# Altium schematic sheet PiggySat-radio.SchDoc(PiggySat-radio)
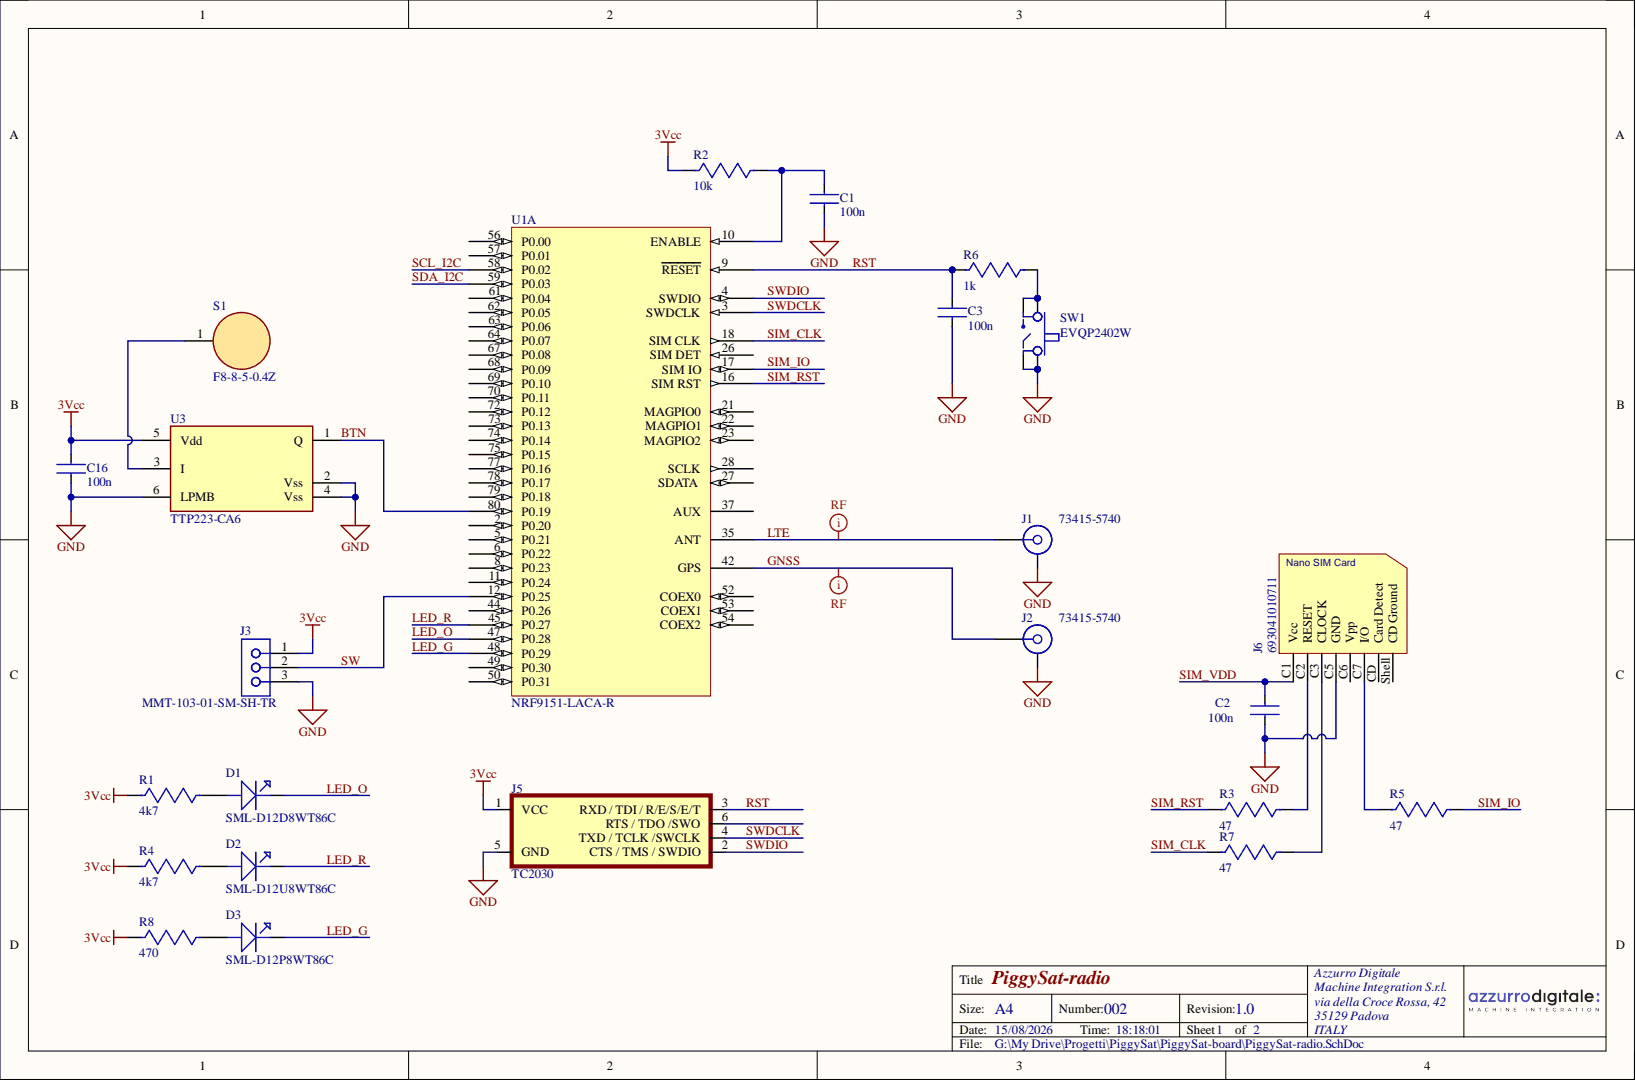
[diagram p0: PiggySat-radio.SchDoc]

## Parts
C1  100n  [CAPC1608X06L]
C2  100n  [CAPC1608X06L]
C3  100n  [CAPC1608X06L]
C16  100n  [CAPC1608X06L]
D1  SML-D12D8WT86C  [SML-D12]
D2  SML-D12U8WT86C  [SML-D12]
D3  SML-D12P8WT86C  [SML-D12]
J1  73415-5740  [MOLEX_734155740]
J2  73415-5740  [MOLEX_734155740]
J3  MMT-103-01-SM-SH-TR  [MMT-103-01-SM-SH-TR]
J5  TC2030  [TC2030-IDC]  (no BOM)
J6  693041010711  [693041010711]
R1  4k7  [RESC1005X04L]
R2  10k  [RESC1005X04L]
R3  47  [RESC1005X04L]
R4  4k7  [RESC1005X04L]
R5  47  [RESC1005X04L]
R6  1k  [RESC1005X04L]
R7  47  [RESC1005X04L]
R8  470  [RESC1005X04L]
S1  F8-8-5-0.4Z  [F8-8-5-0.4Z]
SW1  EVQP2402W  [EVQP2402W]
U1  NRF9151-LACA-R  [FP-nRF9151-LACA-R_MFG]  (part A)
U3  TTP223-CA6  [SOT-23-6L]

## Nets
3Vcc: C16.1 J3.1 J5.1(VCC) R1.1 R2.1 R4.1 R8.1 U3.5(Vdd)
GND: C1.2 C2.1 C3.1 C16.2 J1.2 J2.2 J3.3 J5.5(GND) J6.C5(GND) SW1.1 SW1.3 U3.2(Vss) U3.4(Vss) U3.6(LPMB)
BTN: U1.80(P0.19) U3.1(Q)
GNSS: J2.1 U1.42(GPS)
LED_G: D3.2 U1.48(P0.29)
LED_O: D1.2 U1.47(P0.28)
LED_R: D2.2 U1.45(P0.27)
LTE: J1.1 U1.35(ANT)
RST: C3.2 J5.3 R6.2 U1.9(RESET)
SCL_I2C: U1.58(P0.02)
SDA_I2C: U1.59(P0.03)
SIM_CLK: R7.1 U1.18(SIM_CLK)
SIM_IO: R5.2 U1.17(SIM_IO)
SIM_RST: R3.1 U1.16(SIM_RST)
SIM_VDD: C2.2 J6.C1(Vcc)
SW: J3.2 U1.12(P0.25)
SWDCLK: J5.4(TXD_/_TCLK_/SWCLK) U1.3(SWDCLK)
SWDIO: J5.2 U1.4(SWDIO)
NetC1_1: C1.1 R2.2 U1.10(ENABLE)
NetD1_1: D1.1 R1.2
NetD2_1: D2.1 R4.2
NetD3_1: D3.1 R8.2
NetJ6_C2: J6.C2(RESET) R3.2
NetJ6_C3: J6.C3(CLOCK) R7.2
NetJ6_C7: J6.C7(I/O) R5.1
NetR6_1: R6.1 SW1.2 SW1.4
NetS1_1: S1.1 U3.3(I)

Not connected: J5 6(RTS_/_TDO_/SWO); J6 C6(Vpp) CD(Card_Detect) Shell(CD_Ground); U1 2(P0.20) 5(P0.21) 6(P0.22) 8(P0.23) 11(P0.24) 21(MAGPIO0) 22(MAGPIO1) 23(MAGPIO2) 26(SIM_DET) 27(SDATA) 28(SCLK) 37(AUX) 44(P0.26) 49(P0.30) 50(P0.31) 52(COEX0) 53(COEX1) 54(COEX2) 56(P0.00) 57(P0.01) 61(P0.04) 62(P0.05) 63(P0.06) 64(P0.07) 67(P0.08) 68(P0.09) 69(P0.10) 70(P0.11) 72(P0.12) 73(P0.13) 74(P0.14) 75(P0.15) 77(P0.16) 78(P0.17) 79(P0.18)

## Library data of the parts
C1-C3 C16 = CMP-001-00001-3: Cap 16V 100n X7R 0603 10%; Component Class: Capacitors; Component Kind: Standard; Library Name: AZZURRODIGITALE MACHINE INTEGRATION SRL
D1 = CMP-007-000019-1: LED, 0603, Orange, SMD, 0,8mm x 1,6mm, 20 mA, 2.2 V, 620 nm; Cod. Alt.: (); Cod. Mag.: LV-SMD-0805; Comment1: LED0805; Cod. Fornitore: (); Component Kind: Standard; Design Item ID: SML-D12D8WT86C; Fornitore: (); Montaggio: (); Prezzo: (); Source: Altium_Library.SchLib; Library Name: AZZURRODIGITALE MACHINE INTEGRATION SRL
D2 = CMP-007-000019-1: LED, 0603, Red, SMD, 0,8mm x 1,6mm, 20 mA, 2.2 V, 620 nm; Cod. Alt.: (); Cod. Mag.: LV-SMD-0805; Comment1: LED0805; Cod. Fornitore: (); Component Kind: Standard; Design Item ID: SML-D12U8WT86C; Fornitore: (); Montaggio: (); Prezzo: (); Source: Altium_Library.SchLib; Library Name: AZZURRODIGITALE MACHINE INTEGRATION SRL
D3 = CMP-007-000018-1: LED, 0603, Green, SMD, 0,8mm x 1,6mm, 20 mA, 2.2 V, 560 nm; Cod. Alt.: (); Cod. Mag.: LV-SMD-0805; Comment1: LED0805; Cod. Fornitore: (); Component Kind: Standard; Design Item ID: SML-D12P8WT86C; Fornitore: (); Montaggio: (); Prezzo: (); Source: Altium_Library.SchLib; Library Name: AZZURRODIGITALE MACHINE INTEGRATION SRL
J1 J2 = 73415-5740: SMP PLUG, PCB EDGE MNT, SMOOTH B; Manufacturer: MOLEX; System: Connector; Component Kind: Standard; ComponentLink1Description: Manufacturer URL; ComponentLink1URL: http://www.rosenberger.de; ComponentLink2Description: Datasheet; ComponentLink2URL: http://rosenberger.de/ok/images/documents/db/32K145-400L5.pdf; ComponentLink3Description: Package Specification; ComponentLink3URL: http://rosenberger.de/ok/images/documents/db/32K145-400L5.pdf; ComponentLink4Description: Series Overview / Design Note; ComponentLink4URL: http://rosenberger.de/ok/images/documents/spez/32.pdf; ComponentLink5Description: Webshop / RFQ; ComponentLink5URL: http://www.rosenberger.com/en/contact/sales/; Mounting Technology: Surface Mount; Library Name: PiggySat.IntLib
J3 = MMT-103-01-SM-SH-TR: MMT Series 2.00 mm Horizontal Surface Mount Terminal Strip; System: Connector; Component Kind: Standard; Library Name: PiggySat.IntLib
J5 = CMP-002-000000-4: Serial cable 6-pin “Legged” Tag-Connector.; Library Name: AZZURRODIGITALE MACHINE INTEGRATION SRL
J6 = CMP-03913-167535528-1: Component Kind: Standard; Library Name: Altium Content Vault
R1 R4 = CMP-009-00001-2: Res 4.7k 0402; Component Kind: Standard; Library Name: AZZURRODIGITALE MACHINE INTEGRATION SRL
R2 = CMP-009-00001-2: Res 10k 0402; Component Kind: Standard; Library Name: AZZURRODIGITALE MACHINE INTEGRATION SRL
R3 R5 R7 = CMP-009-00001-2: Res 47 0402; Component Kind: Standard; Library Name: AZZURRODIGITALE MACHINE INTEGRATION SRL
R6 = CMP-009-00001-2: Res 1k 0402; Component Kind: Standard; Library Name: AZZURRODIGITALE MACHINE INTEGRATION SRL
R8 = CMP-009-00001-2: Res 470 0402; Component Kind: Standard; Library Name: AZZURRODIGITALE MACHINE INTEGRATION SRL
S1 = F8-8-5-0.4Z: Capacitive Touch Contact Spring, Conical Ø8/Ø5 mm, 8 mm Height, Ø0.4 mm Wire, 3 mm THT Lead, Nickel-Plated Carbon Steel; Component Kind: Standard; Library Name: PiggySat.IntLib
SW1 = CMP-017-000001-1: Light Touch Switches/EVQP2/P9/3P2; Component Kind: Standard; Design Item ID: EVQP2402W; Source: Altium_Library.SchLib; Library Name: AZZURRODIGITALE MACHINE INTEGRATION SRL
U1 = CMP-00045-2: Lower Power SIP for cellular IoT and DECT NR+, Compatible with nRF Cloud Services; Bandwidth: 1.5GHz; Clock Frequency: 2.2GHz; Data Rate: 3.4Mbps; Interface: 2-Wire, I2C, I2S, SPI, UART, USB; Max Supply Voltage: 5.5V; Min Supply Voltage: 1.7V; Number of ADC Channels: 8; Number of GPIO: 32; Ambient Temperature Range High: 85°C; Component Kind: Standard; Height: 1.3mm; Max Operating Temperature: 85°C; Min Operating Temperature: -40°C; RoHS: Compliant; Library Name: AZZURRODIGITALE MACHINE INTEGRATION SRL
U3 = TTP223-CA6: 1 KEY TOUCH PAD DETECTOR IC; MF: Mini-Circuits; MP: WP4G1+; Check_prices: https://www.snapeda.com/parts/WP4G1+/Mini-Circuits/view-part/?ref=eda; Component Kind: Standard; Package: VQFN-12 Mini-Circuits; SnapEDA_Link: https://www.snapeda.com/parts/WP4G1+/Mini-Circuits/view-part/?ref=snap; Library Name: PiggySat.IntLib
